# Altium schematic sheet 1. RASP&EEPROM.SchDoc("1. RASP&EEPROM")
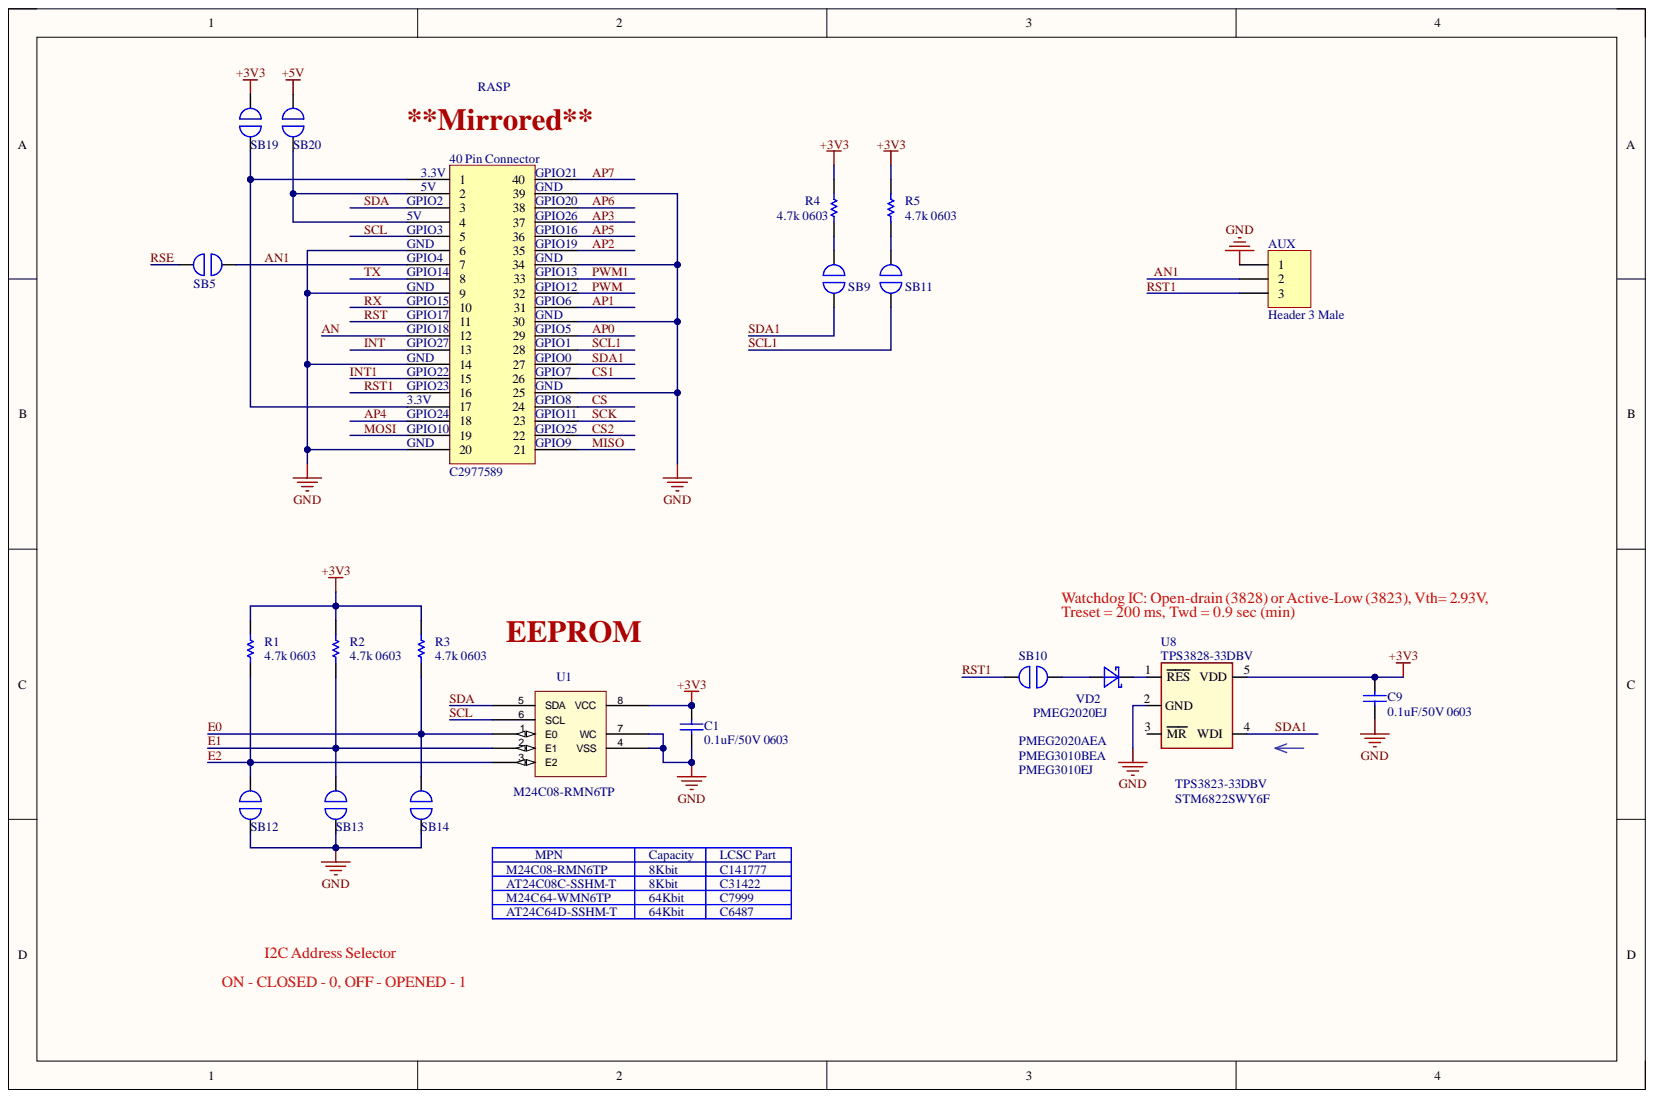
[diagram p0: 1. RASP&EEPROM.SchDoc]

## Parts
AUX  Header 3 Male  [CON_1X03_2.54MM_MALE_STRAIGHT]
C1  0.1uF/50V 0603  [CAPC1608X100N]
C9  0.1uF/50V 0603  [CAPC1608X100N]
R1  4.7k 0603  [RESC1608X50N]
R2  4.7k 0603  [RESC1608X50N]
R3  4.7k 0603  [RESC1608X50N]
R4  4.7k 0603  [RESC1608X50N]
R5  4.7k 0603  [RESC1608X50N]
RASP  C2977589  [HDR-TH_40P-P2.54-V-F-R2-C20-S2.54_MIRROR]
SB5  SOLDER_JUMPER_NO  [SOLDER_JUMPER_NO_2]  (value not drawn)  (no BOM)
SB9  SOLDER_JUMPER_NO  [SOLDER_JUMPER_NO_2]  (value not drawn)  (no BOM)
SB10  SOLDER_JUMPER_NO  [SOLDER_JUMPER_NO_2]  (value not drawn)  (no BOM)
SB11  SOLDER_JUMPER_NO  [SOLDER_JUMPER_NO_2]  (value not drawn)  (no BOM)
SB12  SOLDER_JUMPER_NO  [SOLDER_JUMPER_NO_2]  (value not drawn)  (no BOM)
SB13  SOLDER_JUMPER_NO  [SOLDER_JUMPER_NO_2]  (value not drawn)  (no BOM)
SB14  SOLDER_JUMPER_NO  [SOLDER_JUMPER_NO_2]  (value not drawn)  (no BOM)
SB19  SOLDER_JUMPER_NO  [SOLDER_JUMPER_NO_2]  (value not drawn)  (no BOM)
SB20  SOLDER_JUMPER_NO  [SOLDER_JUMPER_NO_2]  (value not drawn)  (no BOM)
U1  M24C08-RMN6TP  [SOIC127P600X175-8N]
U8  TPS3828-33DBV  [SOT23-5 realistic]
VD2  PMEG2020EJ  [SOD323_uni]

## Nets
+3V3: C1.2 C9.2 R1.1 R2.1 R3.1 R4.1 R5.1 SB19.1 U1.8 U8.5
+5V: SB20.1
GND: AUX.1 C1.1 C9.1 RASP.6 RASP.9 RASP.14 RASP.20 RASP.25 RASP.30 RASP.34 RASP.39 SB12.2 SB13.2 SB14.2 U1.4 U1.7 U8.2
AN: RASP.12
AN1: AUX.2 RASP.7 SB5.2
AP0: RASP.29
AP1: RASP.31
AP2: RASP.35
AP3: RASP.37
AP4: RASP.18
AP5: RASP.36
AP6: RASP.38
AP7: RASP.40
CS: RASP.24
CS1: RASP.26
CS2: RASP.22
E0: R3.2 SB14.1 U1.1
E1: R2.2 SB13.1 U1.2
E2: R1.2 SB12.1 U1.3
INT: RASP.13
INT1: RASP.15
MISO: RASP.21
MOSI: RASP.19
PWM: RASP.32
PWM1: RASP.33
RSE: SB5.1
RST: RASP.11
RST1: AUX.3 RASP.16 SB10.2
RX: RASP.10
SCK: RASP.23
SCL: RASP.5 U1.6
SCL1: RASP.28 SB11.2
SDA: RASP.3 U1.5
SDA1: RASP.27 SB9.2 U8.4
TX: RASP.8
NetR4_2: R4.2 SB9.1
NetR5_2: R5.2 SB11.1
NetRASP_1: RASP.1 RASP.17 SB19.2
NetRASP_2: RASP.2 RASP.4 SB20.2
NetSB10_1: SB10.1 VD2.1
NetU8_1: U8.1 VD2.2

Not connected: U8 3

## Library data of the parts
AUX = Header 3: Gold 250V 3A Straight Square Pins 2.54mm 3P 260℃ 6mm -40℃~+105℃ 3mm 2.54mm Brass Black Plugin 2.54mm 1x3P Plugin,P=2.54mm Pin Headers ROHS; Manufacturer: Hanbo Electronic; Manufacturer Part Number: HB-PH3-25413PB2GOP; Component Kind: Standard; Library Name: Miscellaneous Connectors.IntLib; Supplier 1: LCSC; Supplier Part Number 1: C6332196
C1 C9 = Cap: 50V 100nF X7R ±10% 0603 Multilayer Ceramic Capacitors MLCC - SMD/SMT ROHS; Manufacturer: Walsin Tech Corp; Manufacturer Part Number: 0603B104K500CT; Component Kind: Standard; Library Name: Miscellaneous Devices.IntLib; Signal Integrity: Cap; Simulation: CAP; Supplier 1: LCSC; Supplier Part Number 1: C314282; Value: 0.1uF/50V 0603
R1-R5 = Res1: 100mW Thick Film Resistors ±100ppm/℃ ±1% 4.7kΩ 0603 Chip Resistor - Surface Mount ROHS; Manufacturer: UNI-ROYAL(Uniroyal Elec); Manufacturer Part Number: 0603WAF4701T5E; Component Kind: Standard; Library Name: Miscellaneous Devices.IntLib; Supplier 1: LCSC; Supplier Part Number 1: C23162; Value: 4.7k 0603
RASP = Header 2x20_MIRROR: 2.54mm Plugin 20P Brass Straight 2x20P Plugin,P=2.54mm Female Headers ROHS; Manufacturer: JILN; Manufacturer Part Number: 22850120ANG1SYA01; Component Kind: Standard; Signal Integrity: Connector; Supplier 1: LCSC; Supplier Part Number 1: C429947; Library Name: Bokra.SCHLIB
SB5 SB9-SB14 SB19 SB20 = SOLDER_JUMPER_NO: Solder Jumper; Library Name: Schematic_Lib.SchLib
U1 = M34E02-FMC6TG: EEPROM  IC 8Kb I2C 400 kHz 900 ns 8-SOIC; Manufacturer: STMicroelectronics; Manufacturer Part Number: M24C64-FMC6TG; Component Kind: Standard; Supplier 1: LCSC; Supplier Part Number 1: C141777; Library Name: IoTbase PICO 3-03.SCHLIB
U8 = TPS3828-33DBV: -40℃~+85℃@(Ta) 1 1.1V~5.5V 15uA 2.93V 200ms Active Low Open Drain Power Supervisor Yes Yes SOT-23-5 Supervisor and Reset ICs ROHS; Component Kind: Standard; Library Name: BTGV6_0814.SchLib; Value: TPS3828-33DBV
VD2 = PMEG2020EJ: Shottky diode SOD323; Component Kind: Standard; Library Name: BTGV6_0814.SchLib; Value: PMEG2020EJ
